# Altium schematic sheet Main.SchDoc
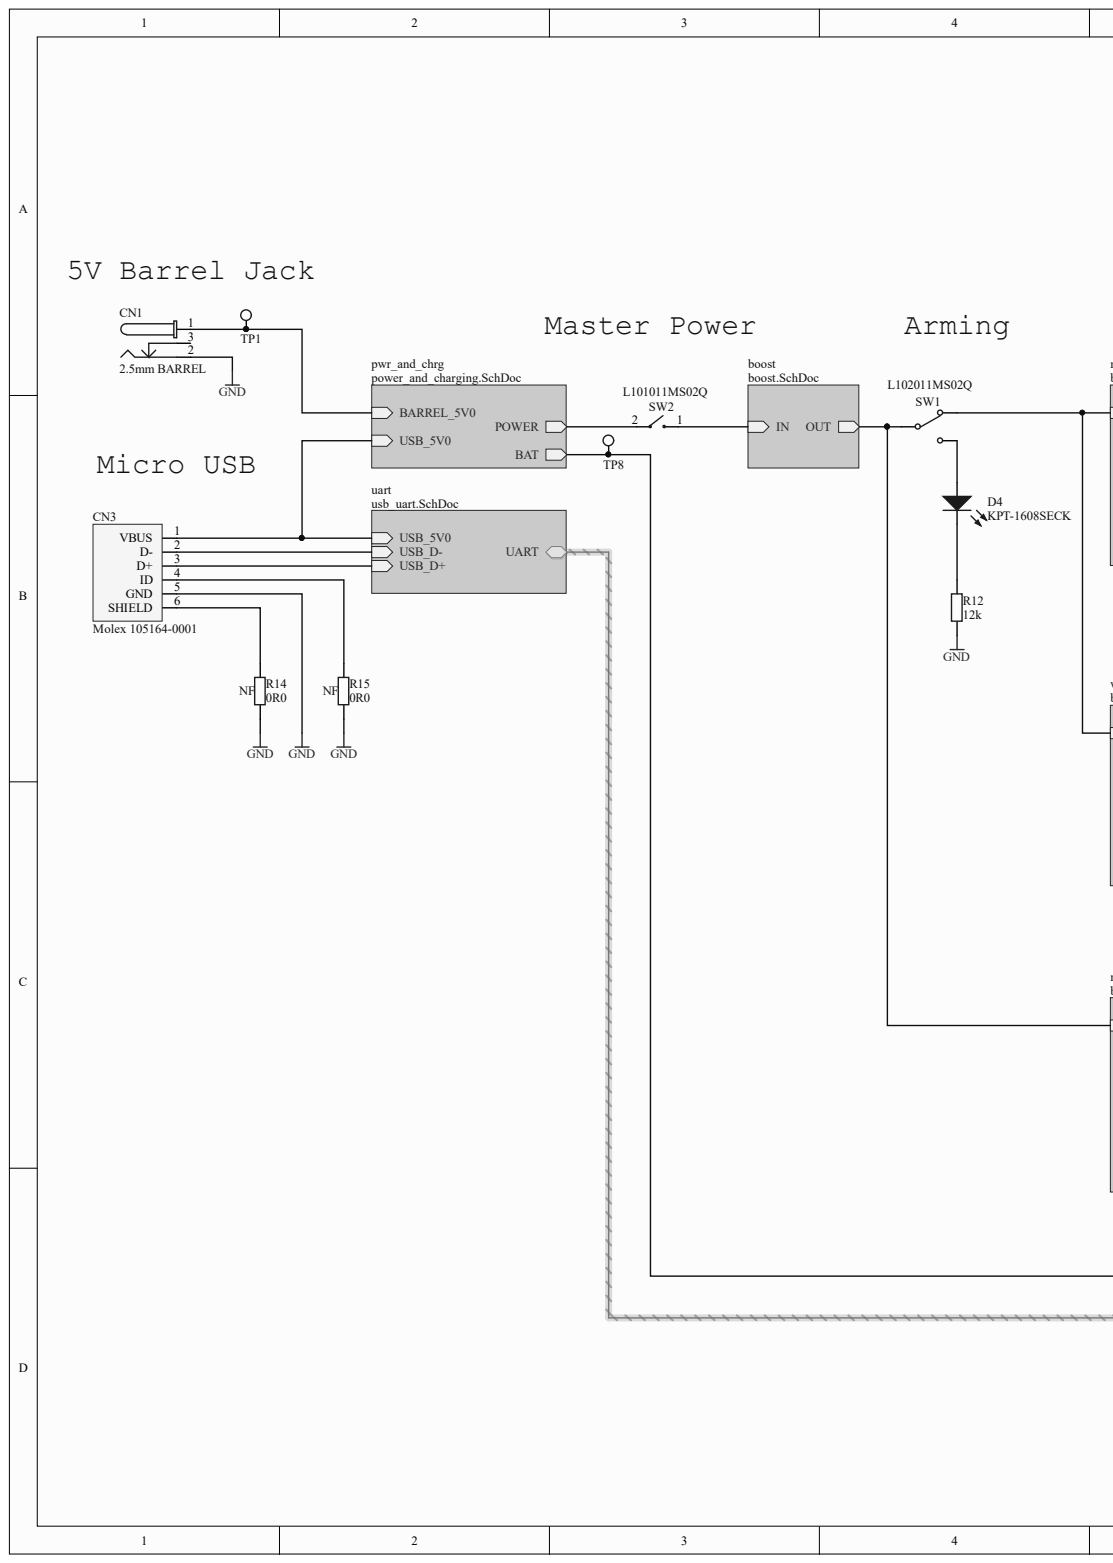
[diagram p0: Main.SchDoc, left]
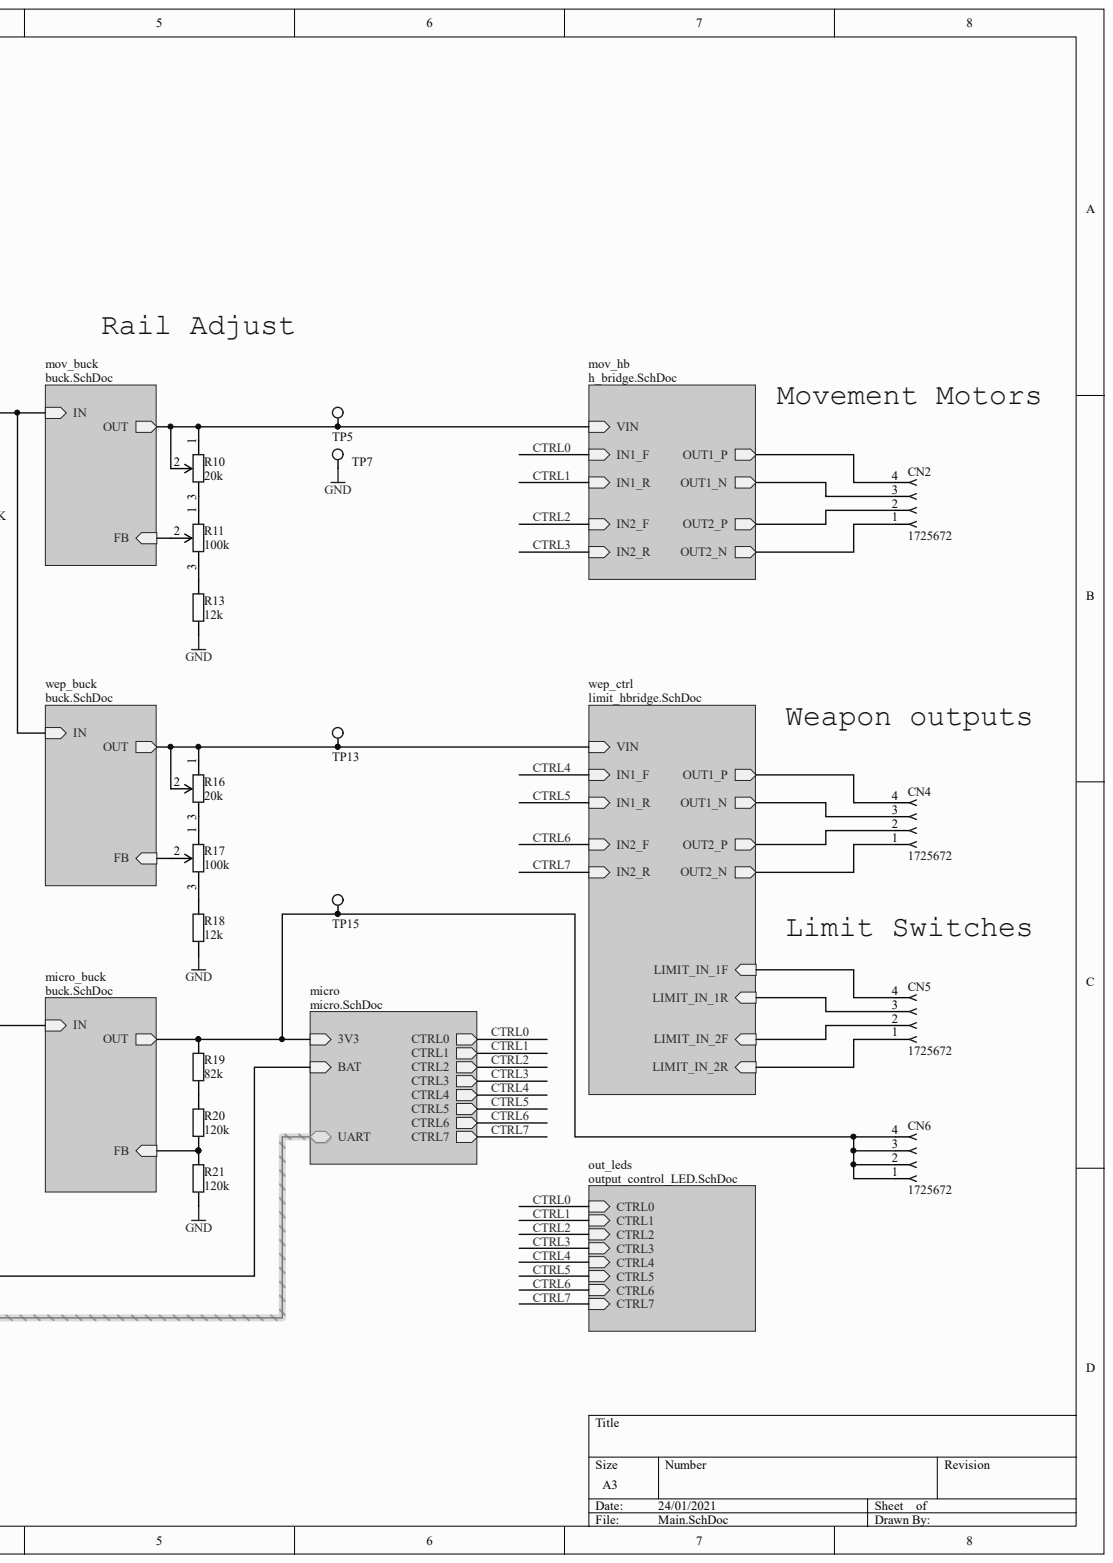
[diagram p1: Main.SchDoc, right]

## Parts
CN1  2.5mm BARREL  [PCB-7q3q10d24ip08komymp0-1]
CN2  1725672  [PCB-9d075knpehwjte7l8o5j-1]
CN3  Molex 105164-0001  Manufacturer Part Number: 105164-0001  [PCB-lkhri9bbjmvp10og7sep-1]
CN4  1725672  [PCB-9d075knpehwjte7l8o5j-1]
CN5  1725672  [PCB-9d075knpehwjte7l8o5j-1]
CN6  1725672  [PCB-9d075knpehwjte7l8o5j-1]
D4  KPT-1608SECK  [PCB-vq1pb75xotxnzx0208jl-1]
R10  20k  [PCBComponent_1 - duplicate]
R11  100k  [PCBComponent_1 - duplicate]
R12  12k  [PCB-hqqq141yp5osftjcwedl-1]
R13  12k  [PCB-hqqq141yp5osftjcwedl-1]
R14  0R0  [PCBComponent_1]
R15  0R0  [PCBComponent_1]
R16  20k  [PCBComponent_1 - duplicate]
R17  100k  [PCBComponent_1 - duplicate]
R18  12k  [PCB-hqqq141yp5osftjcwedl-1]
R19  82k  [PCB-0f091vm5nxewctmhjoyi-1]
R20  120k  [PCB-zny41vjojt4q43cos8xg-1]
R21  120k  [PCB-zny41vjojt4q43cos8xg-1]
SW1  L102011MS02Q  [PCB-l42cwwc6ep0m93ltqbz9-1]
SW2  L101011MS02Q  [PCB-widopg79zii1aaer1kn3-1]
TP1  Keystone 5006  [PCB-a6m4wwq1w8vztsn322mx-1]  (value not drawn)
TP5  Keystone 5006  [PCB-a6m4wwq1w8vztsn322mx-1]  (value not drawn)
TP7  Keystone 5006  [PCB-a6m4wwq1w8vztsn322mx-1]  (value not drawn)
TP8  Keystone 5006  [PCB-a6m4wwq1w8vztsn322mx-1]  (value not drawn)
TP13  Keystone 5006  [PCB-a6m4wwq1w8vztsn322mx-1]  (value not drawn)
TP15  Keystone 5006  [PCB-a6m4wwq1w8vztsn322mx-1]  (value not drawn)

## Nets
GND: CN1.2 CN3.5(GND) R12.2 R13.2 R14.1 R15.1 R18.2 R21.1 TP7.1
NetCN1_1: CN1.1 TP1.1
NetCN1_3: CN1.3
NetCN2_1: CN2.1
NetCN2_2: CN2.2
NetCN2_3: CN2.3
NetCN2_4: CN2.4
NetCN3_1: CN3.1(VBUS)
NetCN3_2: CN3.2(D-)
NetCN3_3: CN3.3(D+)
NetCN3_4: CN3.4(ID) R15.2
NetCN3_6: CN3.6(SHIELD) R14.2
NetCN4_1: CN4.1
NetCN4_2: CN4.2
NetCN4_3: CN4.3
NetCN4_4: CN4.4
NetCN5_1: CN5.1
NetCN5_2: CN5.2
NetCN5_3: CN5.3
NetCN5_4: CN5.4
NetCN6_1: CN6.1 CN6.2 CN6.3 CN6.4 R19.1 TP15.1
NetD4_1: D4.1 SW1.3
NetD4_2: D4.2 R12.1
NetR10_1: R10.1 R10.2 TP5.1
NetR10_3: R10.3 R11.1
NetR11_2: R11.2
NetR11_3: R11.3 R13.1
NetR16_1: R16.1 R16.2 TP13.1
NetR16_3: R16.3 R17.1
NetR17_2: R17.2
NetR17_3: R17.3 R18.1
NetR19_2: R19.2 R20.2
NetR20_1: R20.1 R21.2
NetSW1_1: SW1.1
NetSW1_2: SW1.2
NetSW2_1: SW2.1
NetSW2_2: SW2.2
NetTP8_1: TP8.1
CTRL0:
CTRL1:
CTRL2:
CTRL3:
CTRL4:
CTRL5:
CTRL6:
CTRL7:
UART.NDTR:
UART.NRTS:
UART.RX_FROM_MICRO:
UART.TX_TO_MICRO:

## Library data of the parts
CN1 = CMP-2347603-7: Conn Power PIN 2 POS Solder RA Thru-Hole 3 Terminal 1 Port; Current Rating: 3.50 A; Gender: Male; Voltage Rating (DC): 24.0 V; Color: Black; Component Kind: Standard; Contact Material: Brass; Contact Plating: Tin; Library Name: CommunityVault; Mounting Style: Through Hole; Octopart URL: https://octopart.com/kldx-0202-b-kycon-765598; Packaging: Bag; RoHS: Compliant
CN2 CN4-CN6 = CMP-751815-13: Micro Printed With Ic Pitch 4pos 6a 63vac 26-20awg Green Plastic Housing 2.54mm Pitch 7.62mm Length; Number of Contacts: 4; Component Kind: Standard; Library Name: CommunityVault; Mounting Style: PC Board, Screw, Through Hole, PCB; Number of Positions: 4; REACH SVHC Compliance: No SVHC; Size-Height: 8.50 mm; Size-Width: 6.20 mm
CN3 = CMP-693aa168b7d8b6fd-5: Conn Micro USB 2.0 Type B RCP 5 POS 0.65mm Solder RA SMD 5 Terminal 1 Port T/R; Gender: Female; Number of Circuits: 5; Component Kind: Standard; Library Name: CommunityVault; Orientation: Right Angle; Packaging: Reel; REACH SVHC Compliance: No SVHC
D4 = CMP-1d10d4a8b2f2c2d7-6: LED Uni-Color Orange 610nm 2-Pin Chip LED T/R; Forward Voltage: 2.10 V; Viewing Angle: 120 °; Color: Orange; Component Kind: Standard; Library Name: CommunityVault; Manufacturer Part Number: KPT-1608SECK; Mounting Style: Surface Mount; OctopartID: 1d10d4a8b2f2c2d7; Octopart URL: https://octopart.com/kpt-1608seck-kingbright-11700868; REACH SVHC Compliance: No SVHC; RoHS: Compliant
R10 R16 = CMP-c8f703a389c31730-9: Res Cermet Trimmer 20K Ohm 20% 1/4W 1(Elec)/1(Mech)Turn 2.5mm (5 X 5.5 X 2.7mm) J-Hook SMD; Power Rating: 250 mW; Resistance: 20.0 kΩ; Temperature Coefficient: -100 ppm/°C to 100 ppm/°C; Voltage Rating (DC): 200 V; Component Kind: Standard; Library Name: CommunityVault; Octopart URL: https://octopart.com/ts53yj203mr10-vishay-39740498; Operating Temperature: 155 °C (max); Packaging: Cut Tape (CT); REACH SVHC Compliance: No SVHC; Resistance Tolerance: ±20%
R11 R17 = CMP-d1471a1391670e80-3: Res Cermet Trimmer 100K Ohm 20% 1/4W 1(Elec)/1(Mech)Turn 2.5mm (5 X 5.5 X 2.7mm) J-Hook SMD T/R; Manufacturer Part Number: TS53YJ104MR10; Lead-Free Status: Lead Free; Power Rating: 250 mW; Resistance: 100 kΩ; Temperature Coefficient: -100 ppm/°C to 100 ppm/°C; Voltage Rating (AC): 200 V; Component Kind: Standard; Library Name: CommunityVault; Mounting Style: Surface Mount, PCB; OctopartID: d1471a1391670e80; Octopart URL: https://octopart.com/ts53yj104mr10-vishay-39740742; Operating Temperature: 155 °C (max); Packaging: Cut Tape (CT); REACH SVHC Compliance: No SVHC; Resistance Tolerance: ±20%; RoHS: Compliant; Voltage Rating (DC): 200 V
R12 R13 R18 = CMP-1853399-14: Res General Purpose Thick Film 0603 12K Ohm 1% 1/10W ±100ppm/°C Molded SMD Paper T/R; Manufacturer Part Number: RC0603FR-0712KL; Case/Package (SI): 1608; Lead-Free Status: Lead Free; Power Rating: 100 mW; Resistance: 12.0 kΩ; Temperature Coefficient: -100 ppm/°C to 100 ppm/°C; Case/Package: 0603; Component Kind: Standard; Library Name: CommunityVault; Mounting Style: Surface Mount; Number of Pins: 2; OctopartID: ed232764a9c432e4; Octopart URL: https://octopart.com/rc0603fr-0712kl-yageo-55402881; Operating Temperature: 155 °C (max); Packaging: Tape & Reel; REACH SVHC Compliance: No SVHC; Resistance Tolerance: ±1%; RoHS: Compliant; Size-Height: 450 µm; Size-Length: 1.60 mm; Size-Width: 800 µm
R14 R15 = CMP-1871823-3: Res Thick Film 0603 0 Ohm Jumper 1/10W Molded SMD Paper T/R; Component Kind: Standard; Library Name: CommunityVault; NF: NF; OctopartID: beb976f8d2dd617e
R19 = CMP-afc56582eb257002-2: Res Thick Film 0603 82K Ohm 1% 0.1W(1/10W) ±100ppm/C Pad SMD T/R; Manufacturer Part Number: RC0603FR-0782KL; Component Kind: Standard; Library Name: CommunityVault; Octopart URL: https://octopart.com/rc0603fr-0782kl-yageo-55402886; Size-Length: 1.60 mm
R20 R21 = CMP-1853551-7: Res Thick Film 0603 120K Ohm 1% 0.1W(1/10W) ±100ppm/°C Pad SMD T/R; Manufacturer Part Number: RC0603FR-07120KL; Case/Package (SI): 1608; Lead-Free Status: Lead Free; Power Rating: 100 mW; Resistance: 120 kΩ; Temperature Coefficient: -100 ppm/°C to 100 ppm/°C; Voltage Rating (DC): 75.0 V, 50.0 V; Case/Package: 0603; Component Kind: Standard; Library Name: CommunityVault; Mounting Style: Surface Mount; Number of Pins: 2; OctopartID: 0cc5c7bfe0d4d302; Octopart URL: https://octopart.com/rc0603fr-07120kl-yageo-40946039; Operating Temperature: 155 °C (max); Packaging: Tape & Reel; REACH SVHC Compliance: No SVHC; Resistance Tolerance: ±1%; RoHS: Compliant; Size-Height: 450 µm; Size-Length: 1.60 mm; Size-Width: 800 µm
SW1 = CMP-1016d90c2a23cfd1-2: Switch Slide ON ON SPDT Top Slide 4A 250VAC 125VDC PC Pins Thru-Hole Bulk; Lead-Free Status: Lead Free; Component Kind: Standard; Library Name: CommunityVault; Mounting Style: Through Hole, PC Board; OctopartID: 1016d90c2a23cfd1; Orientation: Vertical; RoHS: Compliant
SW2 = CMP-8b0d4211219154ca-8: Switch Slide SPST Top Slide 4A 250VAC 125VDC PC Pins Thru-Hole Bulk; Component Kind: Standard; Library Name: CommunityVault; Manufacturer Part Number: L101011MS02Q
TP1 TP5 TP7 TP8 TP13 TP15 = CMP-eb34223ee69c34f3-20: Analogue Timer, Cam, Assembly Frame, 13, 3 S, 24 H Rohs Compliant: Yes; Component Kind: Standard; Library Name: CommunityVault
